# Altium schematic sheet Power.SchDoc(Power)
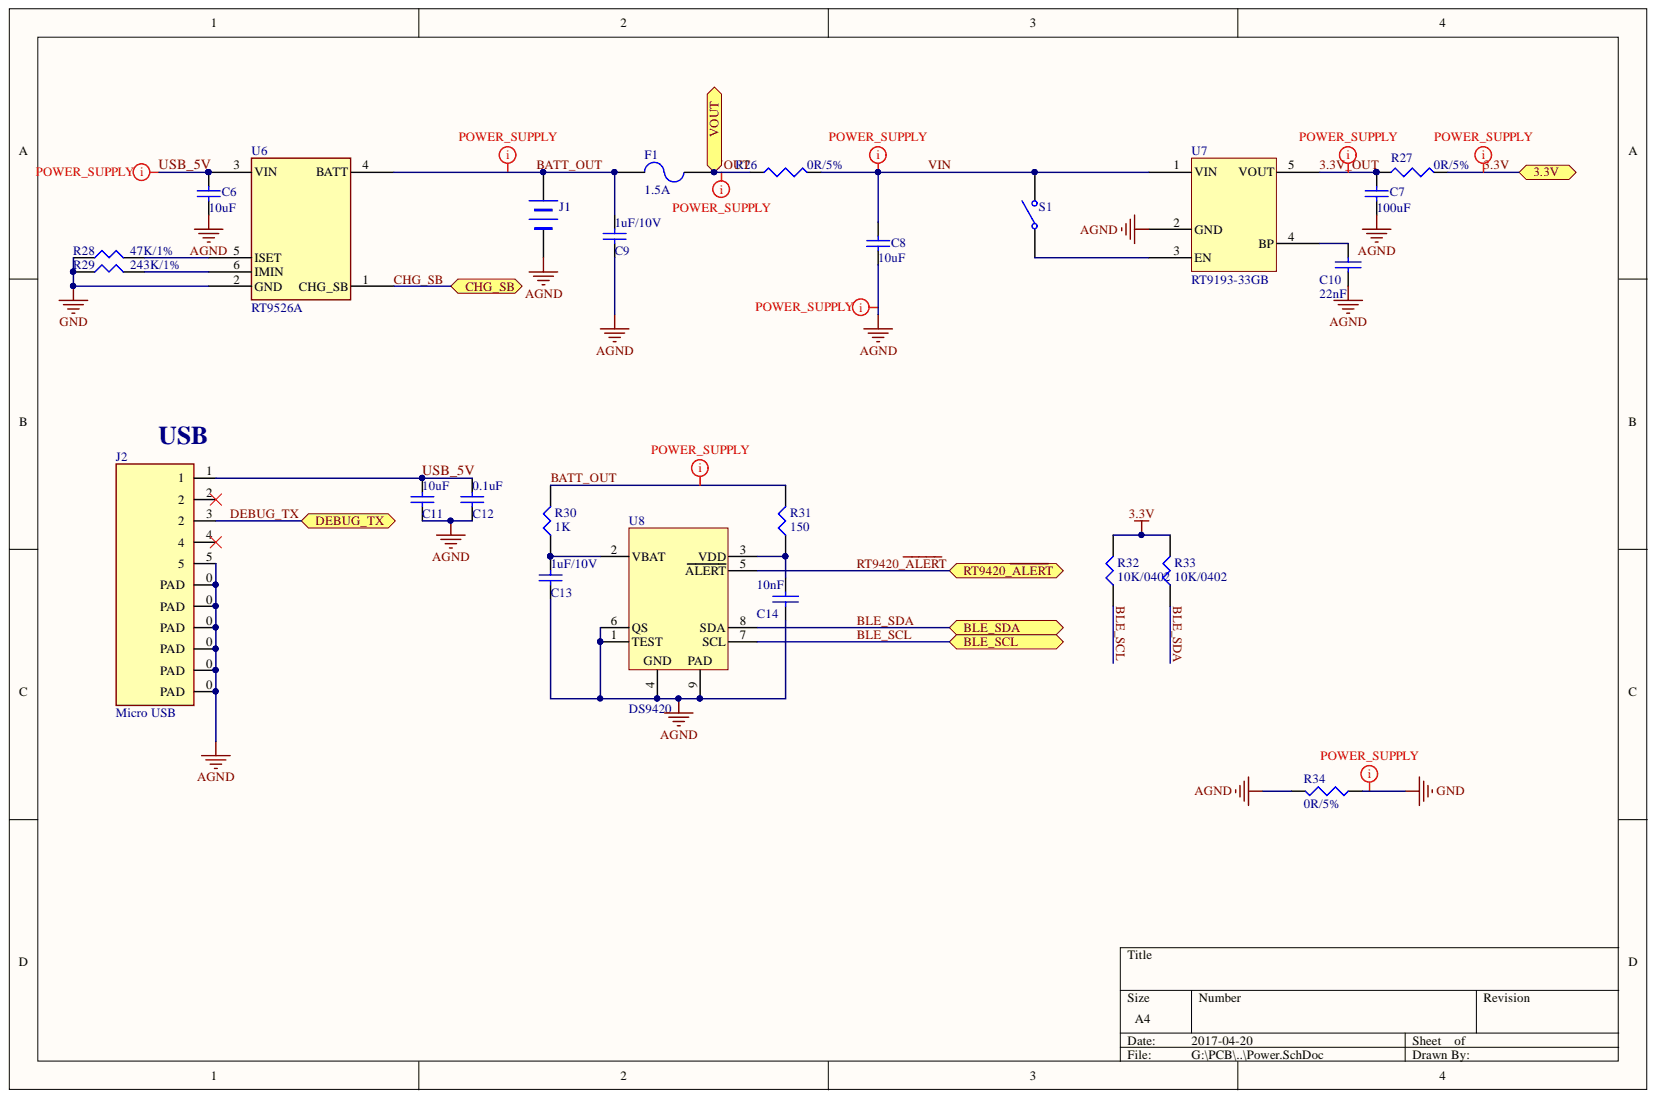
[diagram p0: Power.SchDoc]

## Parts
C6  value 10uF  [0603C-S]  (hidden comment: 10uF/20%)
C7  value 100uF  [0603C-S]  (hidden comment: 10uF/20%)
C8  value 10uF  [0603C-S]  (hidden comment: 10uF/20%)
C9  value 1uF/10V  [0603C-S]  (hidden comment: 1uF/20%)
C10  22nF  [0402C-S]
C11  10uF  [0603C-S]
C12  0.1uF  [0603C-S]
C13  value 1uF/10V  [0603C-S]  (hidden comment: 1uF/20%)
C14  10nF  [0402C-S]
F1  1.5A  [1206]
J1  CR1220 holder  [ATOM-125x2]  (value not drawn)
J2  Micro USB  [Micro-USB-molex]
R26  0R/5%  [0603R-S]
R27  0R/5%  [0603R-S]
R28  47K/1%  [0402R-S]
R29  243K/1%  [0402R-S]
R30  1K  [0402R-S]
R31  150  [0402R-S]
R32  10K/0402  [0402R-S]
R33  10K/0402  [0402R-S]
R34  0R/5%  [0603R-S]
S1  SW-SPST  [SEL-SW-5.8x5.8]  (value not drawn)
U6  RT9526A  [SOT23-6]
U7  RT9193-33GB  [SOT-23-5]
U8  DS9420  [WDFN-8L 2x3]

## Ports
3.3V, BLE_SCL, BLE_SDA, CHG_SB, DEBUG_TX, RT9420_~{ALERT}, VOUT

## Nets
AGND: C6.2 C7.2 C8.2 C9.2 C10.2 C11.2 C12.2 C13.2 C14.2 J1.2 J2.0(PAD) J2.5 R34.2 U7.2(GND) U8.1(TEST) U8.4 U8.6(QS) U8.9
GND: R28.1 R29.1 R34.1 U6.2(GND)
3.3V: R27.2 R32.2 R33.2 ; port 3.3V
3.3V_OUT: C7.1 R27.1 U7.5(VOUT)
BATT_OUT: C9.1 F1.2 J1.1 R30.2 R31.2 U6.4(BATT)
BLE_SCL: R32.1 U8.7(SCL) ; port BLE_SCL
BLE_SDA: R33.1 U8.8(SDA) ; port BLE_SDA
CHG_SB: U6.1(CHG_SB) ; port CHG_SB
DEBUG_TX: J2.3 ; port DEBUG_TX
RT9420_~{ALERT}: U8.5(ALERT) ; port RT9420_~{ALERT}
USB_5V: C6.1 C11.1 C12.1 J2.1 U6.3(VIN)
VIN: C8.1 R26.2 S1.2 U7.1(VIN)
VOUT: F1.1 R26.1 ; port VOUT
NetC10_1: C10.1 U7.4(BP)
NetC13_1: C13.1 R30.1 U8.2(VBAT)
NetC14_1: C14.1 R31.1 U8.3(VDD)
NetR28_2: R28.2 U6.5(ISET)
NetR29_2: R29.2 U6.6(IMIN)
NetS1_1: S1.1 U7.3(EN)

Not connected: J2 2 4

## Library data of the parts
C6-C9 C13 = Cap: Capacitor; Component Kind: Standard; LatestRevisionDate: 17-Jul-2002; LatestRevisionNote: Re-released for DXP Platform.; Library Name: Miscellaneous Devices.IntLib; PackageDescription: Radial Cap, Thru-Hole; 2 Leads; 0.3 in Pin Spacing; PackageReference: RAD-0.3; Published: 8-Jun-2000; Signal Integrity: Cap; Simulation: CAP; Publisher: Altium Limited
C10 C14 = CAP: 0402; Component Kind: Standard; Library Name: MoJi LIB.SchLib
C11 C12 = C: 0402; Component Kind: Standard; Published: 8-Jun-2000; Revision: July-2002: Re-released for DXP Platform.; Signal Integrity: Cap Semi; Simulation: CAP; Library Name: 器件库.SCHLIB; Publisher: Altium Limited
F1 = FUSE2: 厂家：万瑞和，型号：WH1206-150; Component Kind: Standard; Library Name: S_1.SCHLIB
J1 = BATTERY: Component Kind: Standard; Description: Battery; Library Name: STM32_Discovery.SCHLIB
R26 R27 = Res3: Resistor; Component Kind: Standard; LatestRevisionDate: 29-May-2009; LatestRevisionNote: IPC-7351 Footprint Added.; Library Name: Miscellaneous Devices.IntLib; PackageDescription: Chip Resistor; PackageReference: J1-0603; Published: 8-Jun-2000; Signal Integrity: Res; Simulation: RESISTOR; Value: 0R/5%; Publisher: Altium Limited
R28 R30-R33 = RES: Component Kind: Standard
  R28 R30 R31: Library Name: S_1.SCHLIB
  R32 R33: Library Name: AirNut Library.SchLib
R29 = RES1: 10K/5%，0402; Component Kind: Standard
R34 = Res3: Vender :            ; Type :; Component Kind: Standard; LatestRevisionDate: 29-May-2009; LatestRevisionNote: IPC-7351 Footprint Added.; Library Name: Miscellaneous Devices.IntLib; PackageDescription: Chip Resistor; PackageReference: J1-0603; Published: 8-Jun-2000; Signal Integrity: Res; Simulation: RESISTOR; Value: 0R/5%; Publisher: Altium Limited
S1 = SW-SPST: Single-Pole, Single-Throw Switch; Component Kind: Standard; LatestRevisionDate: 17-Jul-2002; LatestRevisionNote: Re-released for DXP Platform.; PackageDescription: Switch; 2 Leads; PackageReference: SPST-2; Published: 24-Mar-1999; Library Name: AirNut Library.SchLib; Publisher: Altium Limited
U6 = RT9526: Charger IC; Component Kind: Standard; Library Name: S_1.SCHLIB
U8 = DS9420: 电池管理; Component Kind: Standard; Library Name: AirNut Library.SchLib
J2 = Micro USB: Component Kind: Standard; Library Name: S_2.SCHLIB
U7 = RT9193-33GB: Component Kind: Standard; Library Name: AirNut Library.SchLib
